# Altium schematic sheet DesignFile.SchDoc
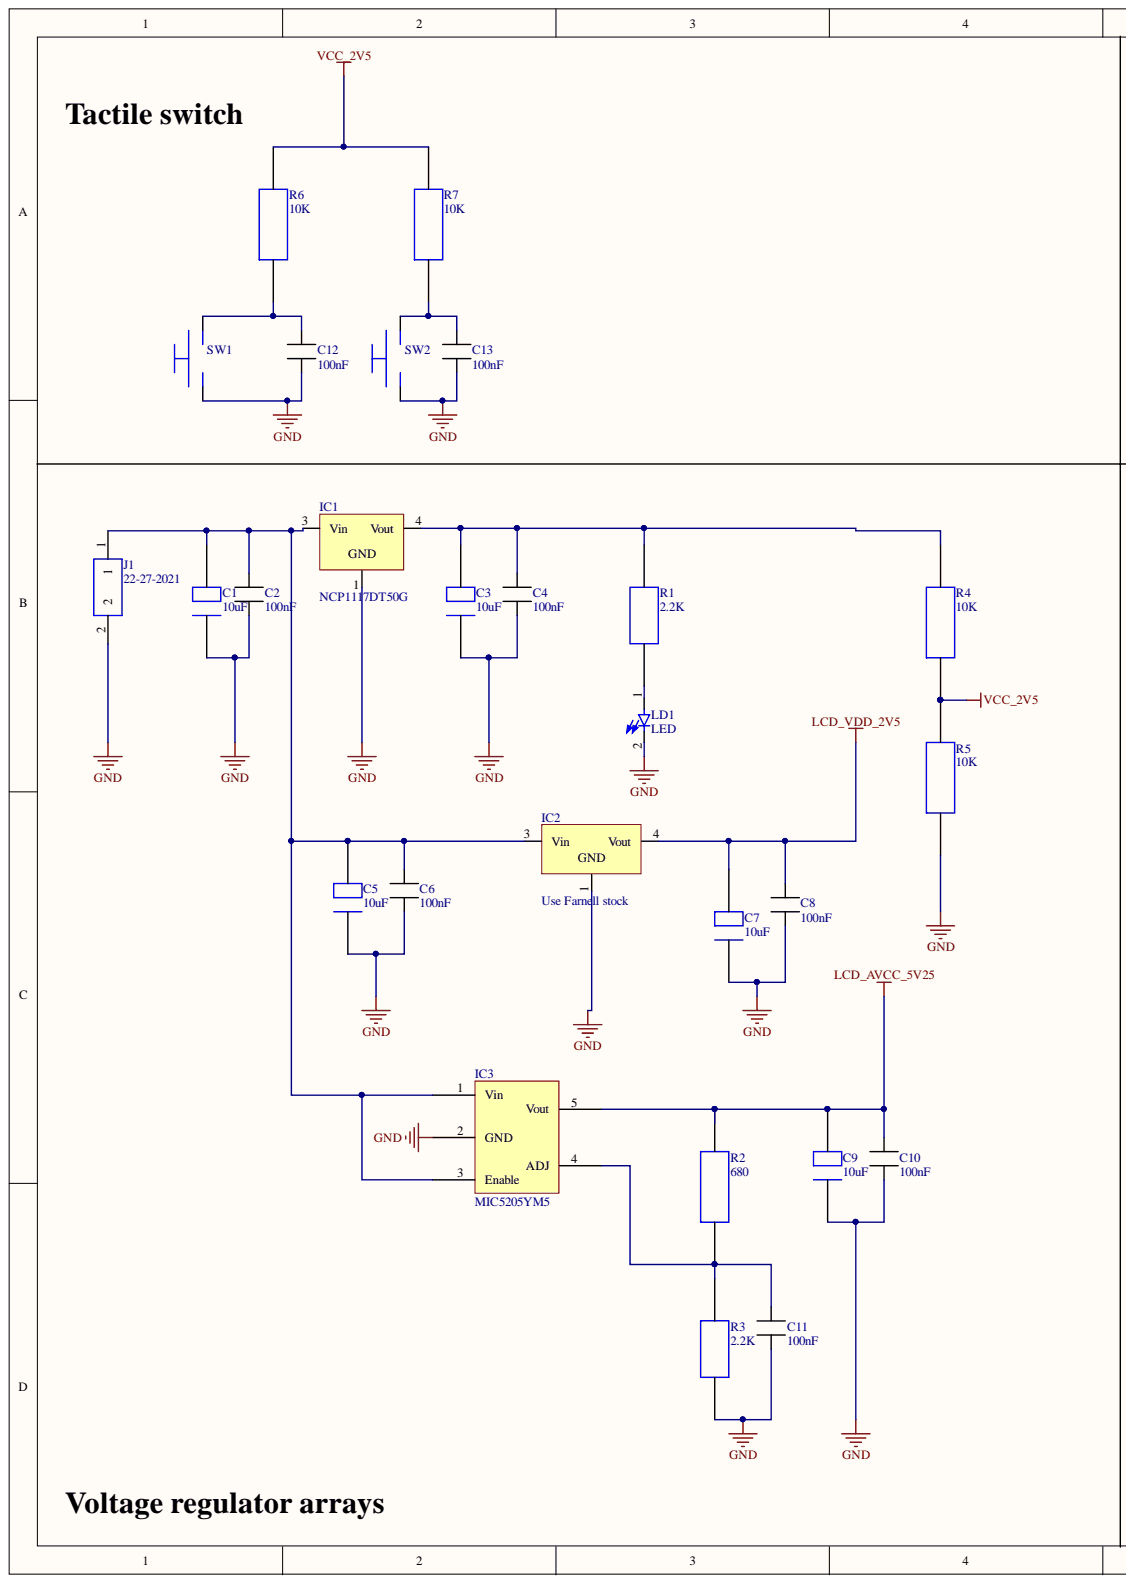
[diagram p0: DesignFile.SchDoc, left]
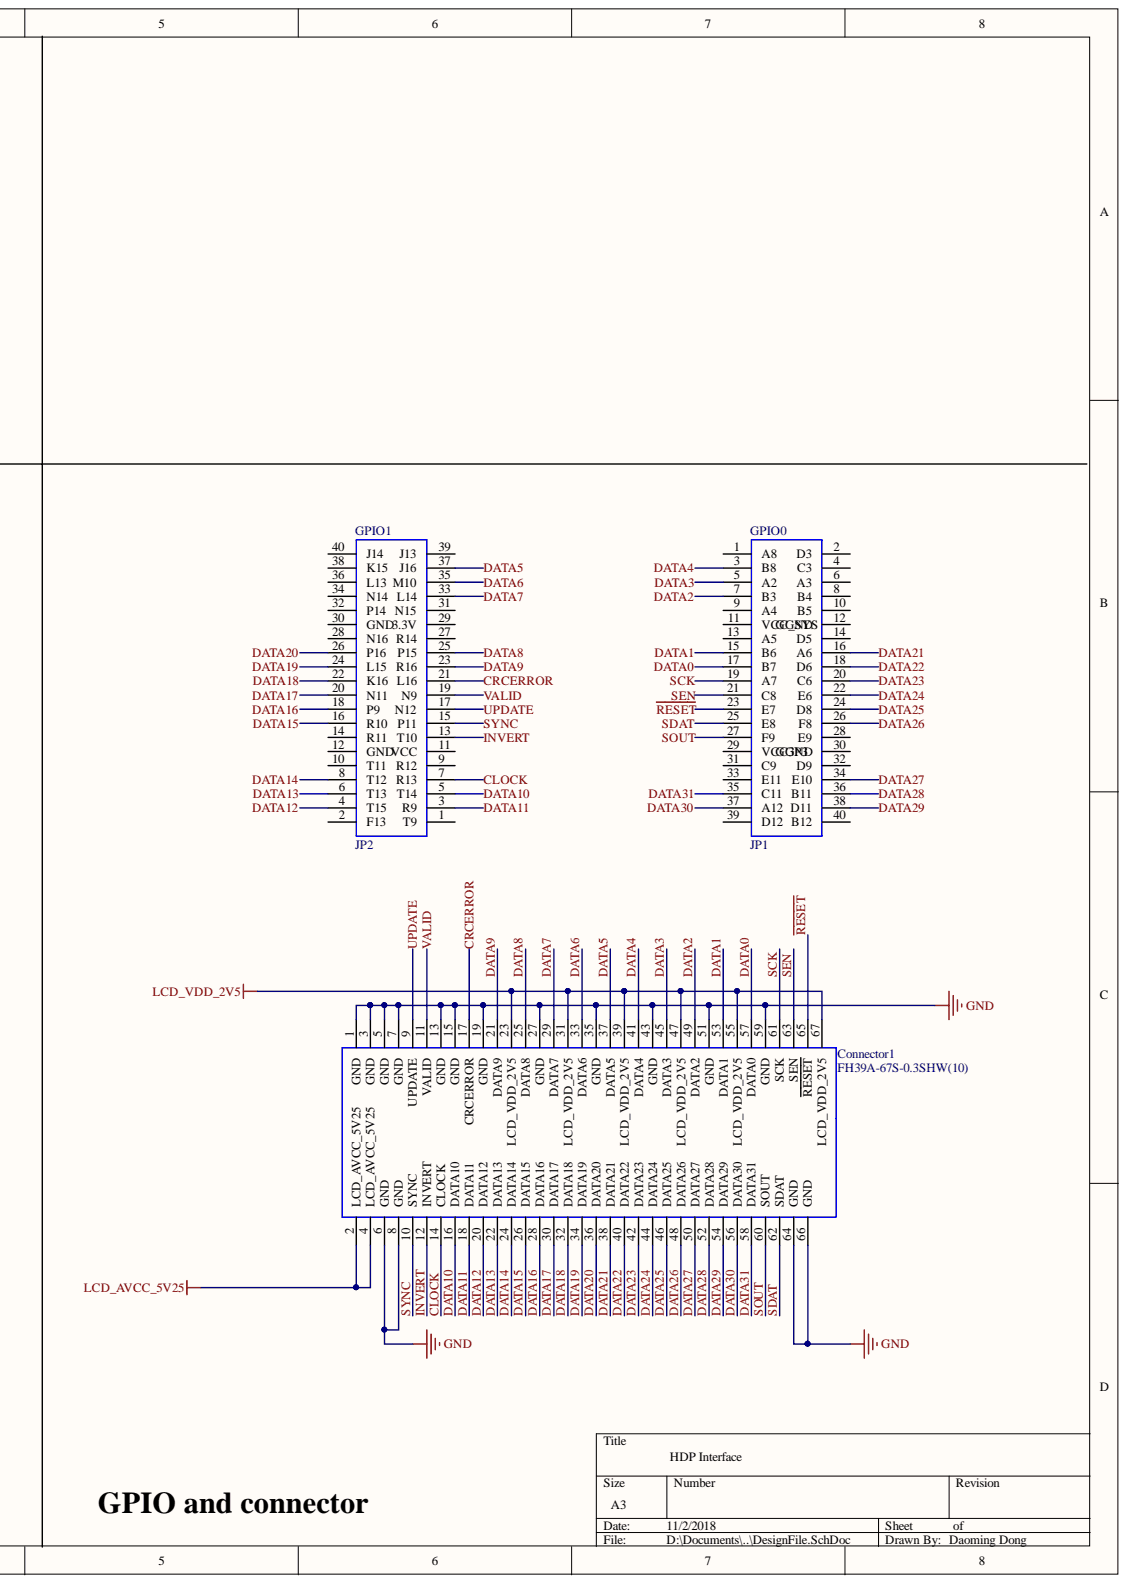
[diagram p1: DesignFile.SchDoc, right]

## Parts
C1  value 10uF  [CAPAE3633X55M]  (hidden comment: UWX1C100MCL2GB)
C2  value 100nF  [CAPC2112X06N]  (hidden comment: 08055C104KAT2A)
C3  value 10uF  [CAPAE3633X55M]  (hidden comment: UWX1C100MCL2GB)
C4  value 100nF  [CAPC2112X06N]  (hidden comment: 08055C104KAT2A)
C5  value 10uF  [CAPAE3633X55M]  (hidden comment: UWX1C100MCL2GB)
C6  value 100nF  [CAPC2112X06N]  (hidden comment: 08055C104KAT2A)
C7  value 10uF  [CAPAE3633X55M]  (hidden comment: UWX1C100MCL2GB)
C8  value 100nF  [CAPC2112X06N]  (hidden comment: 08055C104KAT2A)
C9  value 10uF  [CAPAE3633X55M]  (hidden comment: UWX1C100MCL2GB)
C10  value 100nF  [CAPC2112X06N]  (hidden comment: 08055C104KAT2A)
C11  value 100nF  [CAPC2112X06N]  (hidden comment: 08055C104KAT2A)
C12  value 100nF  [CAPC2112X06N]  (hidden comment: 08055C104KAT2A)
C13  value 100nF  [CAPC2112X06N]  (hidden comment: 08055C104KAT2A)
Connector1  FH39A-67S-0.3SHW(10)  [FH39A-67S-0.3SHW(10)]
GPIO0  JP1  [SHDR40W66P254_2X20_5146X500X1105P]
GPIO1  JP2  [SHDR40W66P254_2X20_5146X500X1105P]
IC1  NCP1117DT50G  [DPAK229P991X238-3N]
IC2  Use Farnell stock  [SOT230P700X180-4N]
IC3  MIC5205YM5  [SOT95P280X145-5N]
J1  22-27-2021  [HDRV2W64P254_2X1_508X580H1118]
LD1  LED  [PCBComponent_1]
R1  value 2.2K  [RESC2012X06N]  (hidden comment: MCWR08X2201FTL)
R2  CR0805-FX-6800GLF  [RESC2012X06N]  (value not drawn)
R3  value 2.2K  [RESC2012X06N]  (hidden comment: MCWR08X2201FTL)
R4  value 10K  [RESC1608X06N]  (hidden comment: MCWR06X1002FTL)
R5  value 10K  [RESC1608X06N]  (hidden comment: MCWR06X1002FTL)
R6  value 10K  [RESC1608X06N]  (hidden comment: MCWR06X1002FTL)
R7  value 10K  [RESC1608X06N]  (hidden comment: MCWR06X1002FTL)
SW1  DTSM-32S-B  [Tactile switch]  (value not drawn)
SW2  DTSM-32S-B  [Tactile switch]  (value not drawn)

## Nets
GND: C1.2 C2.1 C3.2 C4.1 C5.2 C6.1 C7.2 C8.1 C9.2 C10.1 C11.2 C12.1 C13.1 Connector1.1(GND) Connector1.3(GND) Connector1.5(GND) Connector1.6(GND) Connector1.7(GND) Connector1.8(GND) Connector1.13(GND) Connector1.15(GND) Connector1.19(GND) Connector1.27(GND) Connector1.35(GND) Connector1.43(GND) Connector1.51(GND) Connector1.59(GND) Connector1.64(GND) Connector1.66(GND) IC1.1 IC2.1 IC3.2(GND) J1.2 LD1.2 R3.1 R5.1 SW1.1 SW2.1
LCD_AVCC_5V25: C9.1 C10.2 Connector1.2(LCD_AVCC_5V25) Connector1.4(LCD_AVCC_5V25) IC3.5(Vout) R2.2
LCD_VDD_2V5: C7.1 C8.2 Connector1.23(LCD_VDD_2V5) Connector1.31(LCD_VDD_2V5) Connector1.39(LCD_VDD_2V5) Connector1.47(LCD_VDD_2V5) Connector1.55(LCD_VDD_2V5) Connector1.67(LCD_VDD_2V5) IC2.4
VCC_2V5: R4.1 R5.2 R6.2 R7.2
~{RESET}: Connector1.65(RESET) GPIO0.23(E7)
CLOCK: Connector1.14(CLOCK) GPIO1.7(R13)
CRCERROR: Connector1.17(CRCERROR) GPIO1.21(L16)
DATA0: Connector1.57(DATA0) GPIO0.17(B7)
DATA1: Connector1.53(DATA1) GPIO0.15(B6)
DATA2: Connector1.49(DATA2) GPIO0.7(B3)
DATA3: Connector1.45(DATA3) GPIO0.5(A2)
DATA4: Connector1.41(DATA4) GPIO0.3(B8)
DATA5: Connector1.37(DATA5) GPIO1.37(J16)
DATA6: Connector1.33(DATA6) GPIO1.35(M10)
DATA7: Connector1.29(DATA7) GPIO1.33(L14)
DATA8: Connector1.25(DATA8) GPIO1.25(P15)
DATA9: Connector1.21(DATA9) GPIO1.23(R16)
DATA10: Connector1.16(DATA10) GPIO1.5(T14)
DATA11: Connector1.18(DATA11) GPIO1.3(R9)
DATA12: Connector1.20(DATA12) GPIO1.4(T15)
DATA13: Connector1.22(DATA13) GPIO1.6(T13)
DATA14: Connector1.24(DATA14) GPIO1.8(T12)
DATA15: Connector1.26(DATA15) GPIO1.16(R10)
DATA16: Connector1.28(DATA16) GPIO1.18(P9)
DATA17: Connector1.30(DATA17) GPIO1.20(N11)
DATA18: Connector1.32(DATA18) GPIO1.22(K16)
DATA19: Connector1.34(DATA19) GPIO1.24(L15)
DATA20: Connector1.36(DATA20) GPIO1.26(P16)
DATA21: Connector1.38(DATA21) GPIO0.16(A6)
DATA22: Connector1.40(DATA22) GPIO0.18(D6)
DATA23: Connector1.42(DATA23) GPIO0.20(C6)
DATA24: Connector1.44(DATA24) GPIO0.22(E6)
DATA25: Connector1.46(DATA25) GPIO0.24(D8)
DATA26: Connector1.48(DATA26) GPIO0.26(F8)
DATA27: Connector1.50(DATA27) GPIO0.34(E10)
DATA28: Connector1.52(DATA28) GPIO0.36(B11)
DATA29: Connector1.54(DATA29) GPIO0.38(D11)
DATA30: Connector1.56(DATA30) GPIO0.37(A12)
DATA31: Connector1.58(DATA31) GPIO0.35(C11)
INVERT: Connector1.12(INVERT) GPIO1.13(T10)
SCK: Connector1.61(SCK) GPIO0.19(A7)
SDAT: Connector1.62(SDAT) GPIO0.25(E8)
SEN: Connector1.63(SEN) GPIO0.21(C8)
SOUT: Connector1.60(SOUT) GPIO0.27(F9)
SYNC: Connector1.10(SYNC) GPIO1.15(P11)
UPDATE: Connector1.9(UPDATE) GPIO1.17(N12)
VALID: Connector1.11(VALID) GPIO1.19(N9)
NetC1_1: C1.1 C2.2 C5.1 C6.2 IC1.3 IC2.3 IC3.1(Vin) IC3.3(Enable) J1.1
NetC3_1: C3.1 C4.2 IC1.4 R1.2 R4.2
NetC11_1: C11.1 IC3.4(ADJ) R2.1 R3.2
NetC12_2: C12.2 R6.1 SW1.2
NetC13_2: C13.2 R7.1 SW2.2
NetLD1_1: LD1.1 R1.1

Not connected: GPIO0 1(A8) 2(D3) 4(C3) 6(A3) 8(B4) 9(A4) 10(B5) 11 12(VCC_SYS) 13(A5) 14(D5) 28(E9) 29(VCC3P3) 30(GGND) 31(C9) 32(D9) 33(E11) 39(D12) 40(B12); GPIO1 1(T9) 2(F13) 9(R12) 10(T11) 11(VCC) 12(GND) 14(R11) 27(R14) 28(N16) 29(3.3V) 30(GND) 31(N15) 32(P14) 34(N14) 36(L13) 38(K15) 39(J13) 40(J14)

## Library data of the parts
C1 C3 C5 C7 C9 = UWX1C100MCL2GB: UWX1C100MCL2GB -  SMD Aluminium Electrolytic Capacitor, Radial Can - SMD, 10 µF, 16 V, WX Series, 2000 hours @ 85°C; Component Kind: Standard; Datasheet URLs: http://www.farnell.com/datasheets/2097752.pdf; Date created: 13 October 2018; Farnell stock no.: 8823014; Created by: Daoming Dong; Library Name: Full_design_lbr.IntLib
C2 C4 C6 C8 C10-C13 = 08055C104KAT2A: 08055C104KAT2A -  SMD Multilayer Ceramic Capacitor, 0805 [2012 Metric], 0.1 µF, 50 V, ± 10%, X7R, AVX 0805 MLCC; Component Kind: Standard; Datasheet URL: http://www.farnell.com/datasheets/2237835.pdf; Date created: 13 October 2018; Farnell stock no.: 499687; Created by: Daoming Dong; Library Name: Full_design_lbr.IntLib
Connector1 = FH39A-67S-0.3SHW(10): 67 Position FPC Connector Contacts, Top and Bottom 0.012" (0.30mm) Surface Mount, Right Angle; Manufacturer_Name: Hirose; Arrow Part Number: FH39A-67S-0.3SHW(10); Component Kind: Standard; Datasheet Link: https://www.hirose.com/product/en/download_file/key_name/FH39/category/Catalog/doc_file_id/49360/?file_category_id=4&item_id=308&is_series=1; Library Name: 67pins_connector.IntLib; Manufacturer_Part_Number: FH39A-67S-0.3SHW(10)
GPIO0 GPIO1 = M20-6102045: PC / 104 Connectors PC104 STACK CONNECT 20+20 HI TEMP AU; Manufacturer_Name: HARWIN; Geometry.Height: 11.05mm; Arrow Part Number: M20-6102045; Arrow Price/Stock: http://uk.rs-online.com/web/p/products/1731101; Component Kind: Standard; Datasheet Link: http://docs-europe.electrocomponents.com/webdocs/0d9e/0900766b80d9e5da.pdf; Library Name: M20-6102045.IntLib; Manufacturer_Part_Number: M20-6102045; RS Part Number: 1731101; RS Price/Stock: http://uk.rs-online.com/web/p/products/1731101
IC1 = NCP1117DT50G: NCP1117DT50G -  Fixed LDO Voltage Regulator, 20V in, 1.07V Dropout, 5V/1A out, TO-252-3; Component Kind: Standard; Datasheet URL: http://www.farnell.com/datasheets/2236912.pdf; Date created: 13 October 2018; Farnell stock no.: 2296057; Library Name: NCP1117DT50G.IntLib; Created by: Daoming Dong
IC2 = LM1117MP-2.5/NOPB: The LM1117MP-2.5/NOPB is a low dropout Linear Regulator with a dropout of 2.5V at 800mA of load current. It has the same pin-out as Texas Instruments' industry standard LM317. The LM1117 is available with 2.5V of fixed output voltage. This Linear regulator offers current limiting and thermal shutdown. Its circuit includes a Zener trimmed band gap reference to assure output voltage accuracy to within ±1% and a minimum of 10µF tantalum capacitor is required at the output to improve the transient response and stability.; Component Kind: Standard; Datasheet URL: http://www.ti.com/lit/ds/symlink/lm1117.pdf; Date created: 13 October 2018; Farnell stock no.: 1564629; Library Name: LM1117MP-2.5NOPB.IntLib; RS stock no.: 535-8685; Created by: Daoming Dong
IC3 = MIC5205YM5: MIC5205YM5-TR -  LDO Voltage Regulator, Adjustable, 2.5V to 16V input, 165 mV drop., 150 mA out, SOT-23-5; Component Kind: Standard; Datasheet URL: http://www.farnell.com/datasheets/29605.pdf; Date created: 13th October 2018; Farnell stock no.: 2510227; Library Name: MIC5205YM5.IntLib; Created by: Daoming Dong
J1 = 22-27-2021: 2way straight PCB header w/friction lock Molex KK Series, Series Number 6410, 2.54mm Pitch 2 Way 1 Row Straight PCB Header, Solder Termination, 4A; Geometry.Height: 11.18mm; Arrow Part Number: 22-27-2021; Arrow Price/Stock: http://uk.rs-online.com/web/p/products/4838461P; Component Kind: Standard; Datasheet Link: http://uk.rs-online.com/web/p/pcb-headers/4838461/?source=DS; Library Name: Connector_J1.IntLib; Manufacturer_Name: Molex; Manufacturer_Part_Number: 22-27-2021; RS Part Number: 4838461P; RS Price/Stock: http://uk.rs-online.com/web/p/products/4838461P
LD1 = HSMH-C170: HSMH-C170 -  LED, Red, SMD, 1.25mm x 1.4mm, 20 mA, 1.8 V, 639 nm; Component Kind: Standard; Datasheet URLs: http://www.farnell.com/datasheets/1681524.pdf; Date created: 15 October 2018; Farnell stock no.: 5790840; Library Name: HSMH-C170.IntLib; Created by: Daoming Dong
R1 R3 = MCWR08X2201FTL: MCWR08X2201FTL -  SMD Chip Resistor, 2.2 kohm, MCWR Series, 150 V, Thick Film, 0805 [2012 Metric], 125 mW; Component Kind: Standard; Datasheet URLs: http://www.farnell.com/datasheets/2563624.pdf; Date created: 13 October 2018; Farnell stocn no.: 2447623; Created by: Daoming Dong; Library Name: Full_design_lbr.IntLib
R2 = CR0805-FX-6800GLF: CR0805-FX-6800GLF -  SMD Chip Resistor, 680 ohm, CR Series, 150 V, Thick Film, 0805 [2012 Metric], 125 mW; Values: 680; Component Kind: Standard; Datasheet URLs: http://www.farnell.com/datasheets/1856384.pdf; Date created: 13 October 2018; Farnell stock no.: 2008378; Created by: Daoming Dong; Library Name: Full_design_lbr.IntLib
R4-R7 = MCWR06X1002FTL: MCWR06X1002FTL -  SMD Chip Resistor, 10 kohm, MCWR Series, 50 V, Thick Film, 0603 [1608 Metric], 100 mW; Component Kind: Standard; Datasheet URLs: http://www.farnell.com/datasheets/2563624.pdf; Date created: 13 October 2018; Farnell stock no.: 2447230; Created by: Daoming Dong; Library Name: Full_design_lbr.IntLib
SW1 SW2 = DTSM-32S-B: DTSM-32S-B -  Tactile Switch, Solder, Non Illuminated, DTS Series, 50 mA, 12 V, 3.14 N; Component Kind: Standard; Datasheet URLs: http://www.farnell.com/datasheets/1662013.pdf; Date created: 13 October 2018; Farnell stock no.: 9471898; Functionality: Tactile switch; Library Name: DTSM-32S-B.IntLib; Created by: Daoming Dong
